# Altium schematic sheet Lightproject.SchDoc(Lightproject)
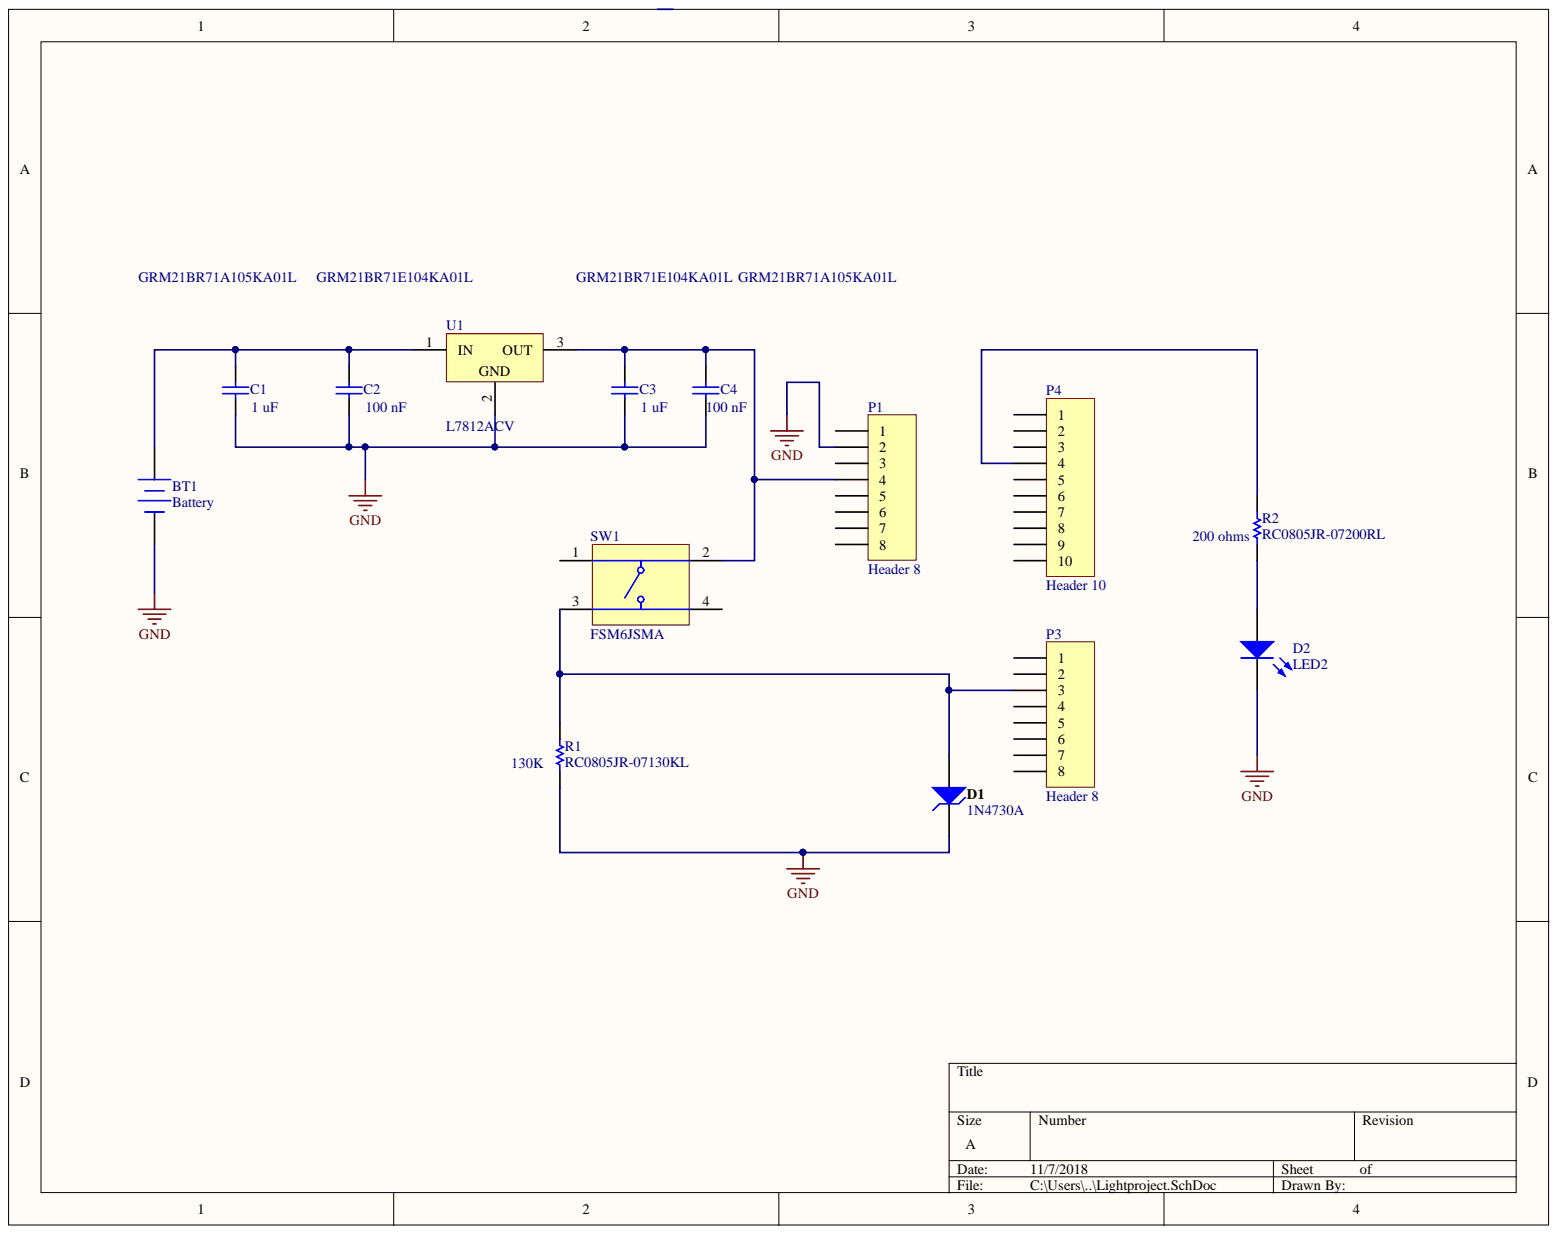
[diagram p0: Lightproject.SchDoc]

## Parts
BT1  Battery  [BAT-2]
C1  GRM21BR71A105KA01L  [CAPC2013X135X45NL10T25]
C2  GRM21BR71E104KA01L  [CAPC2013X135X50ML10T20]
C3  GRM21BR71E104KA01L  [CAPC2013X135X50ML10T20]
C4  GRM21BR71A105KA01L  [CAPC2013X135X45NL10T25]
D1  1N4730A  [FAIR-DO-41-2_V]
D2  LED2  [3.2X1.6X1.1]
P1  Header 8  [HDR1X8]
P3  Header 8  [HDR1X8]
P4  Header 10  [HDR1X10]
R1  RC0805JR-07130KL  [RESC2013X60X35NL10T20]
R2  RC0805JR-07200RL  [RESC2013X60X35ML10T20]
SW1  FSM6JSMA  [TECO-FSM6JSMA_V]
U1  L7812ACV  [TO220]

## Nets
GND: BT1.2 C1.1 C2.1 C3.1 C4.1 D1.2 D2.2 P1.2 R1.1 U1.2
NetBT1_1: BT1.1 C1.2 C2.2 U1.1
NetC3_2: C3.2 C4.2 P1.4 SW1.2 U1.3
NetD1_1: D1.1 P3.3 R1.2 SW1.3
NetD2_1: D2.1 R2.1
NetP4_4: P4.4 R2.2
N00002:

Not connected: P1 1 3 5 6 7 8; P3 1 2 4 5 6 7 8; P4 1 2 3 5 6 7 8 9 10; SW1 1 4

## Library data of the parts
BT1 = Battery: Multicell Battery; Published: 24-Mar-1999; PackageReference: BAT-2; PackageDescription: Battery; 2 Leads; Library Name: Miscellaneous Devices.IntLib; LatestRevisionNote: Re-released for DXP Platform.; LatestRevisionDate: 17-Jul-2002; Component Kind: Standard; Publisher: Altium Limited
C1 C4 = CMP-2007-03551-1: Manufacturer: Murata; Part Number: GRM21BR71A105KA01L; Library Name: Altium Content Vault; Component Kind: Standard
C2 C3 = CMP-2007-03456-1: Manufacturer: Murata; Part Number: GRM21BR71E104KA01L; Library Name: Altium Content Vault; Component Kind: Standard
D1 = CMP-0892-00029-1: Zener Diode, 3.9V, 1W, 5%, -65 to 200 degC, 2-Pin DO-41, Body 2.72 x 5.2 mm, RoHS; Manufacturer: Fairchild Semiconductor; ZZ (Max) (ohm): 9; VZ (Min.) (V): 3.705; VZ (Max.) (V): 4.095; Test Current IZ (mA): 64; PD (W): 1; IR (uA): 50; Tolerance: 5%; RoHS: TRUE; PartNumber: 1N4730A; PackageVersion: Rev. 2; PackageReference: DO-41-2; PackageDescription: 2-Pin Axial leaded, Body 2.72 x 5.2 mm, Lead Spread 25.4 mm; Operating and Storage Temperature Range: -65 to 200 degC; Mounting Technology: Through Hole; Library Name: Altium Content Vault; DatasheetVersion: Rev. H3; ComponentLink3URL: http://www.fairchildsemi.com/dwg/DO/DO41A.pdf; ComponentLink3Description: Package Specification; ComponentLink2URL: http://www.fairchildsemi.com/ds/1N/1N4744A.pdf; ComponentLink2Description: Datasheet; ComponentLink1URL: http://www.fairchildsemi.com/; ComponentLink1Description: Manufacturer URL; Component Kind: Standard
D2 = LED2: Typical RED, GREEN, YELLOW, AMBER GaAs LED; Simulation: LED2; Published: 8-Jun-2000; PackageVersion: Feb-2002; PackageReference: 3.2X1.6X1.1; PackageDescription: SM LED; 2 Flat Leads; Library Name: Miscellaneous Devices.IntLib; LatestRevisionNote: Re-released for DXP Platform.; LatestRevisionDate: 17-Jul-2002; Component Kind: Standard; Publisher: Altium Limited
P1 P3 = Header 8: Header, 8-Pin; Signal Integrity: Connector; Library Name: Miscellaneous Connectors.IntLib; LatestRevisionNote: Re-released for DXP Platform.; LatestRevisionDate: 17-Jul-2002; Component Kind: Standard; Publisher: Altium Limited
P4 = Header 10: Header, 10-Pin; Signal Integrity: Connector; Library Name: Miscellaneous Connectors.IntLib; LatestRevisionNote: Re-released for DXP Platform.; LatestRevisionDate: 17-Jul-2002; Component Kind: Standard; Publisher: Altium Limited
R1 = CMP-2001-01344-1: Manufacturer: Yageo; Part Number: RC0805JR-07130KL; Library Name: Altium Content Vault; Component Kind: Standard
R2 = CMP-2001-01354-1: Manufacturer: Yageo; Part Number: RC0805JR-07200RL; Library Name: Altium Content Vault; Component Kind: Standard
SW1 = CMP-2000-07503-1: Switch, SPST, 24 V, -35 to 85 degC, 4-Pin SMD, RoHS, Tape and Reel; Manufacturer: TE Connectivity; Voltage Rating (DC): 24V; Switch Travel: 0.25mm; Operating Force: 1.57N; Mechanical Life: 100000Cycles; Max Voltage Rating (DC): 12V; Insulation Resistance: 100MOhm; Illumination Type: Illuminated; Current Rating: 50mA; Case\/Package: SMD/SMT; Actuator Material: Polyamide; Actuator Length: 3.4mm; Width: 6mm; Voltage Rating: 24V; Throw Configuration: SPST; Termination: GullWing; RoHSCompliant: true; REACH SVHC: Unknown; Packaging: LoosePiece; Package Reference: FSM6JSMA; Package Quantity: 750; Package Description: 4-Pin Surface Mount Device, Body 6 x 6 mm, Pitch 4.5 mm; Orientation: Straight; Mounting Technology: SurfaceMount; Mount: SurfaceMount; Min Operating Temperature: -35degC; Max Operating Temperature: 85degC; Manufacturer URL: http://www.te.com/; Library Name: Altium Content Vault; Length: 6mm; Lead Free: LeadFree; ELV: Compliant; Electrical Life: 100000Cycles; Datasheet Version: Rev. V, 02/2015; Datasheet URL: http://www.te.com/commerce/DocumentDelivery/DDEController?Action=showdoc&DocId=Customer+Drawing%7F2-1437565-7%7FV%7Fpdf%7FEnglish%7FENG_CD_2-1437565-7_V.pdf%7F4-1437565-9; Contact Resistance: 100mOhm; Contact Plating: Silver; Contact Material: CopperAlloy; Contact Current Rating: 50mA; Component Kind: Standard
U1 = CMP-0244-00451-1: Positive Voltage Regulator, 12V, 3-Pin TO-220; PackageVersion: ver3.0; PackageReference: TO220; PackageDescription: TO, Thru-Hole, Vertical, Heatsink Mounted; 3 In-Line Leads; Pitch 2.54 mm; Library Name: Altium Content Vault; DatasheetVersion: 16/11/2011; ComponentLink3URL: http://www.st.com/internet/com/TECHNICAL_RESOURCES/TECHNICAL_LITERATURE/PACKAGE_INFORMATION/CD00004649.pdf; ComponentLink3Description: Package Specification; ComponentLink2URL: http://www.st.com/internet/com/TECHNICAL_RESOURCES/TECHNICAL_LITERATURE/DATASHEET/CD00000444.pdf; ComponentLink2Description: Datasheet; ComponentLink1URL: http://www.st.com; ComponentLink1Description: Manufacturer URL; Component Kind: Standard
